# Altium schematic sheet Sist_General.SchDoc
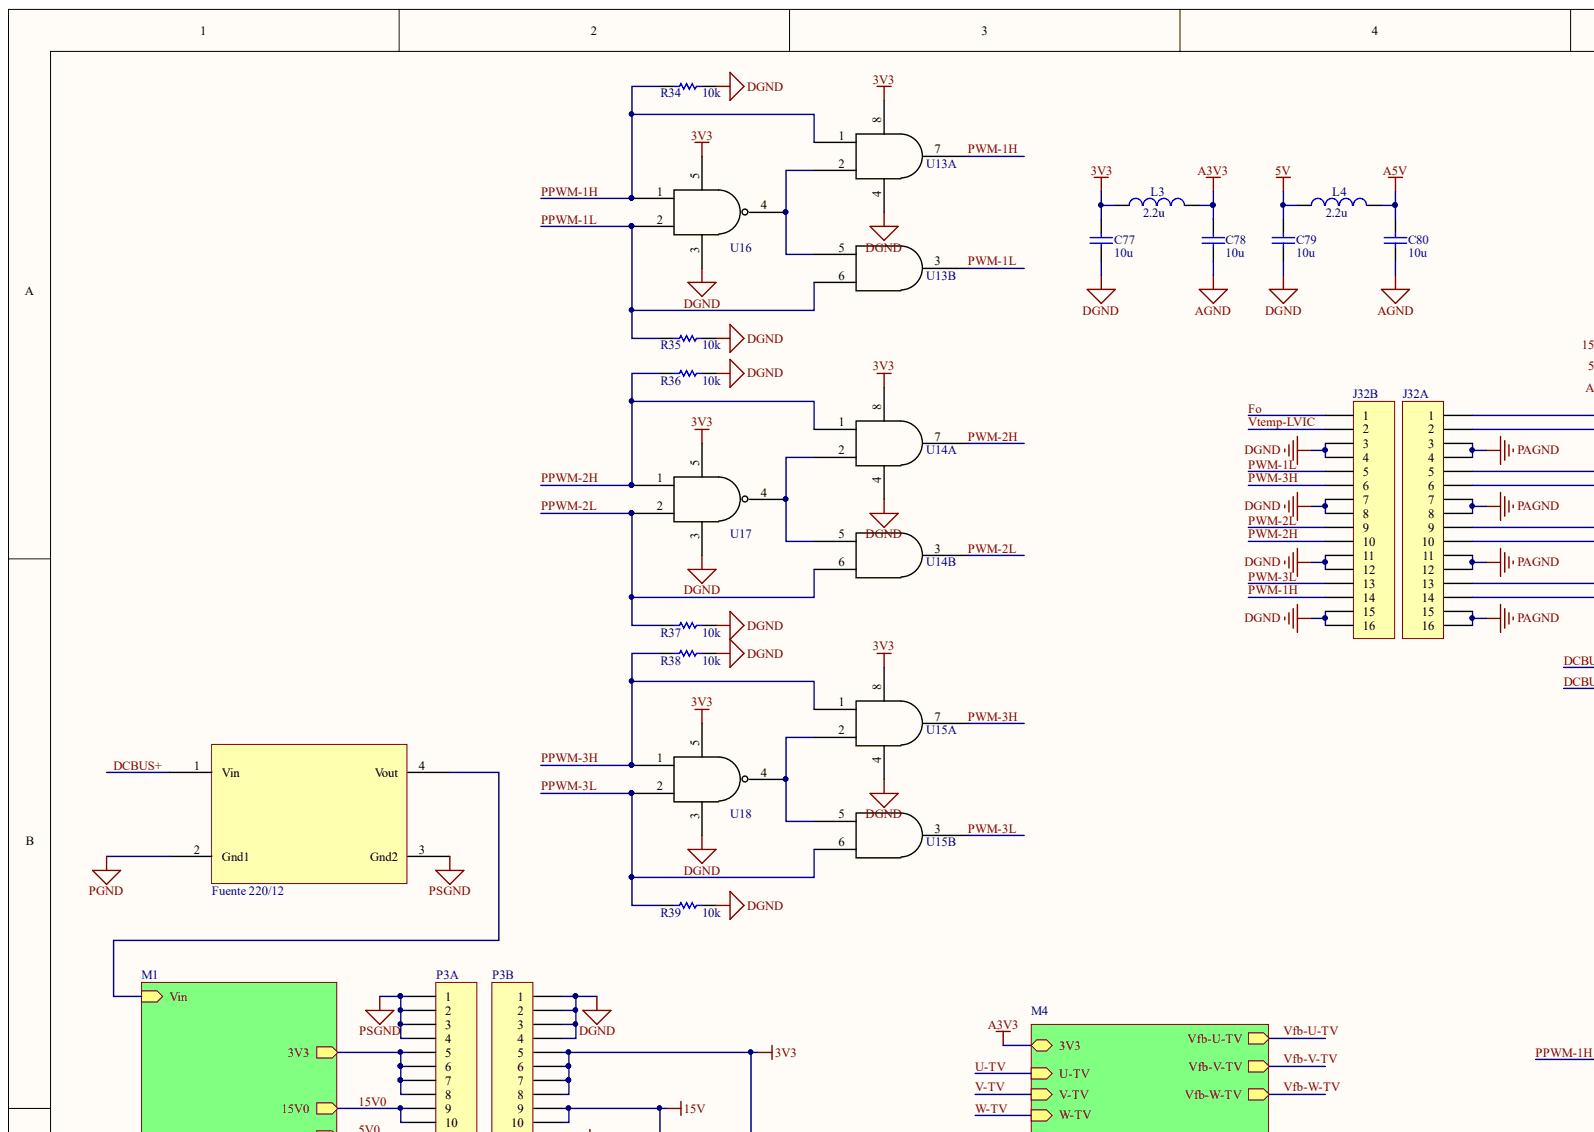
[diagram p0: Sist_General.SchDoc, top left]
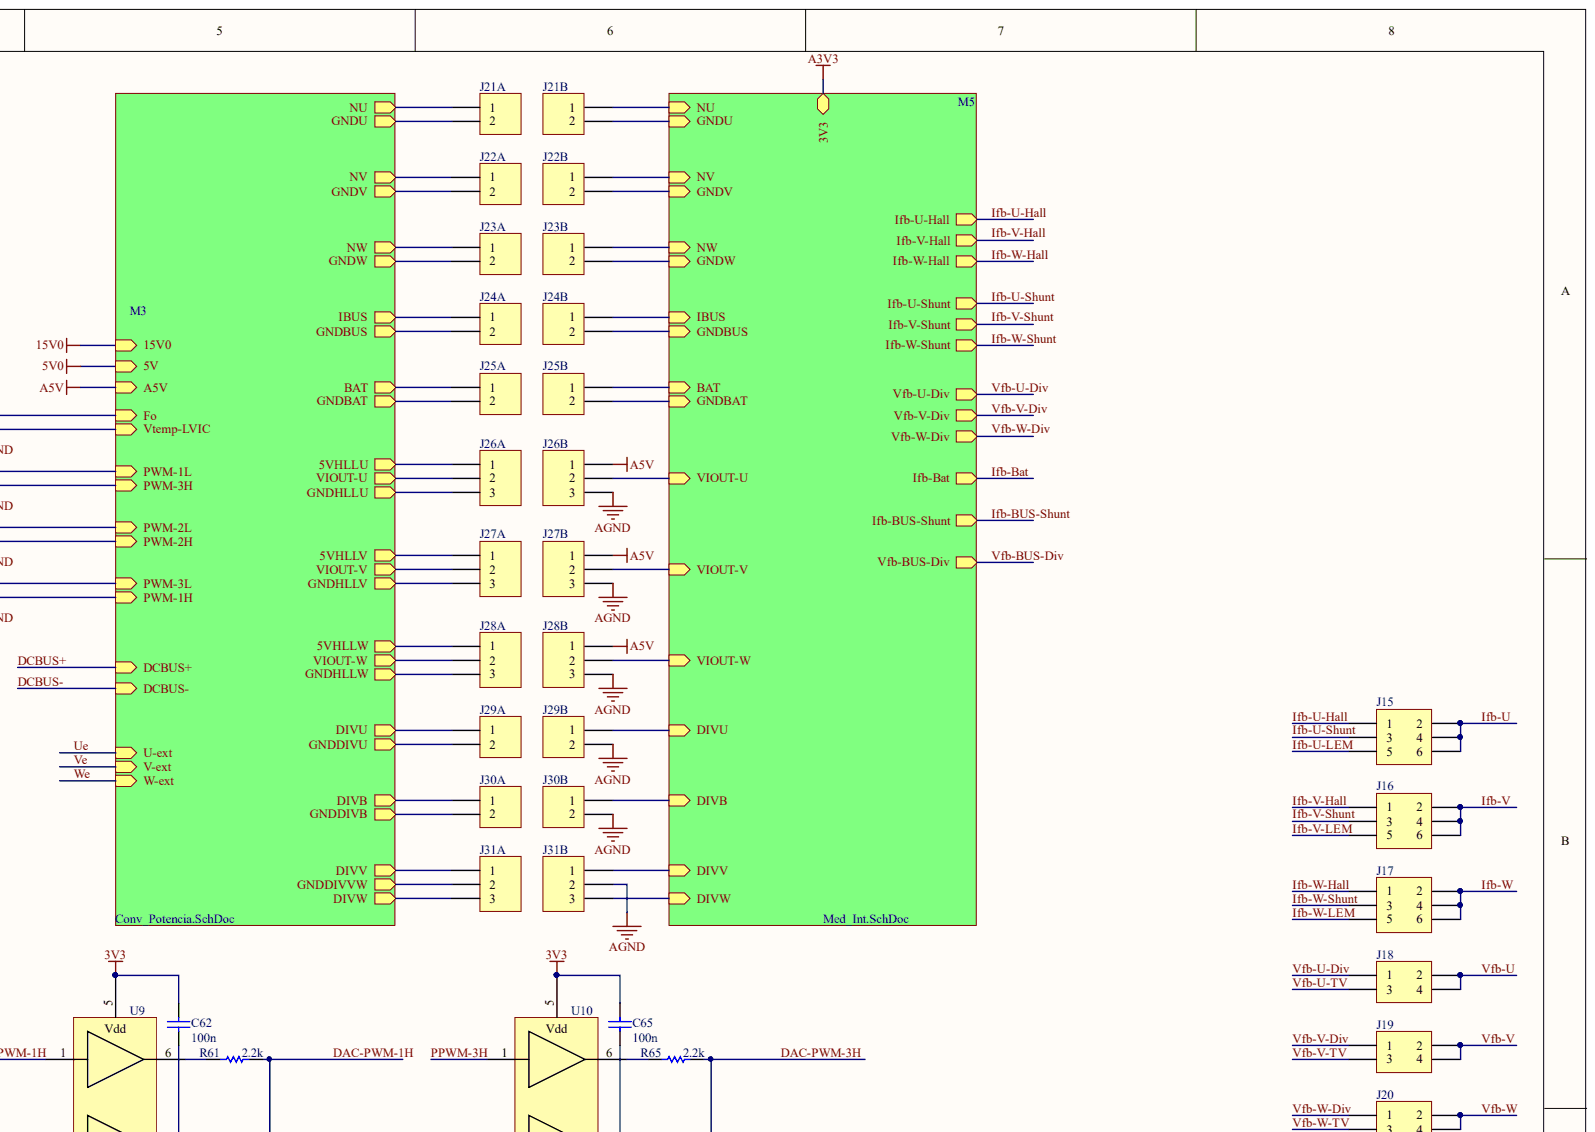
[diagram p1: Sist_General.SchDoc, top right]
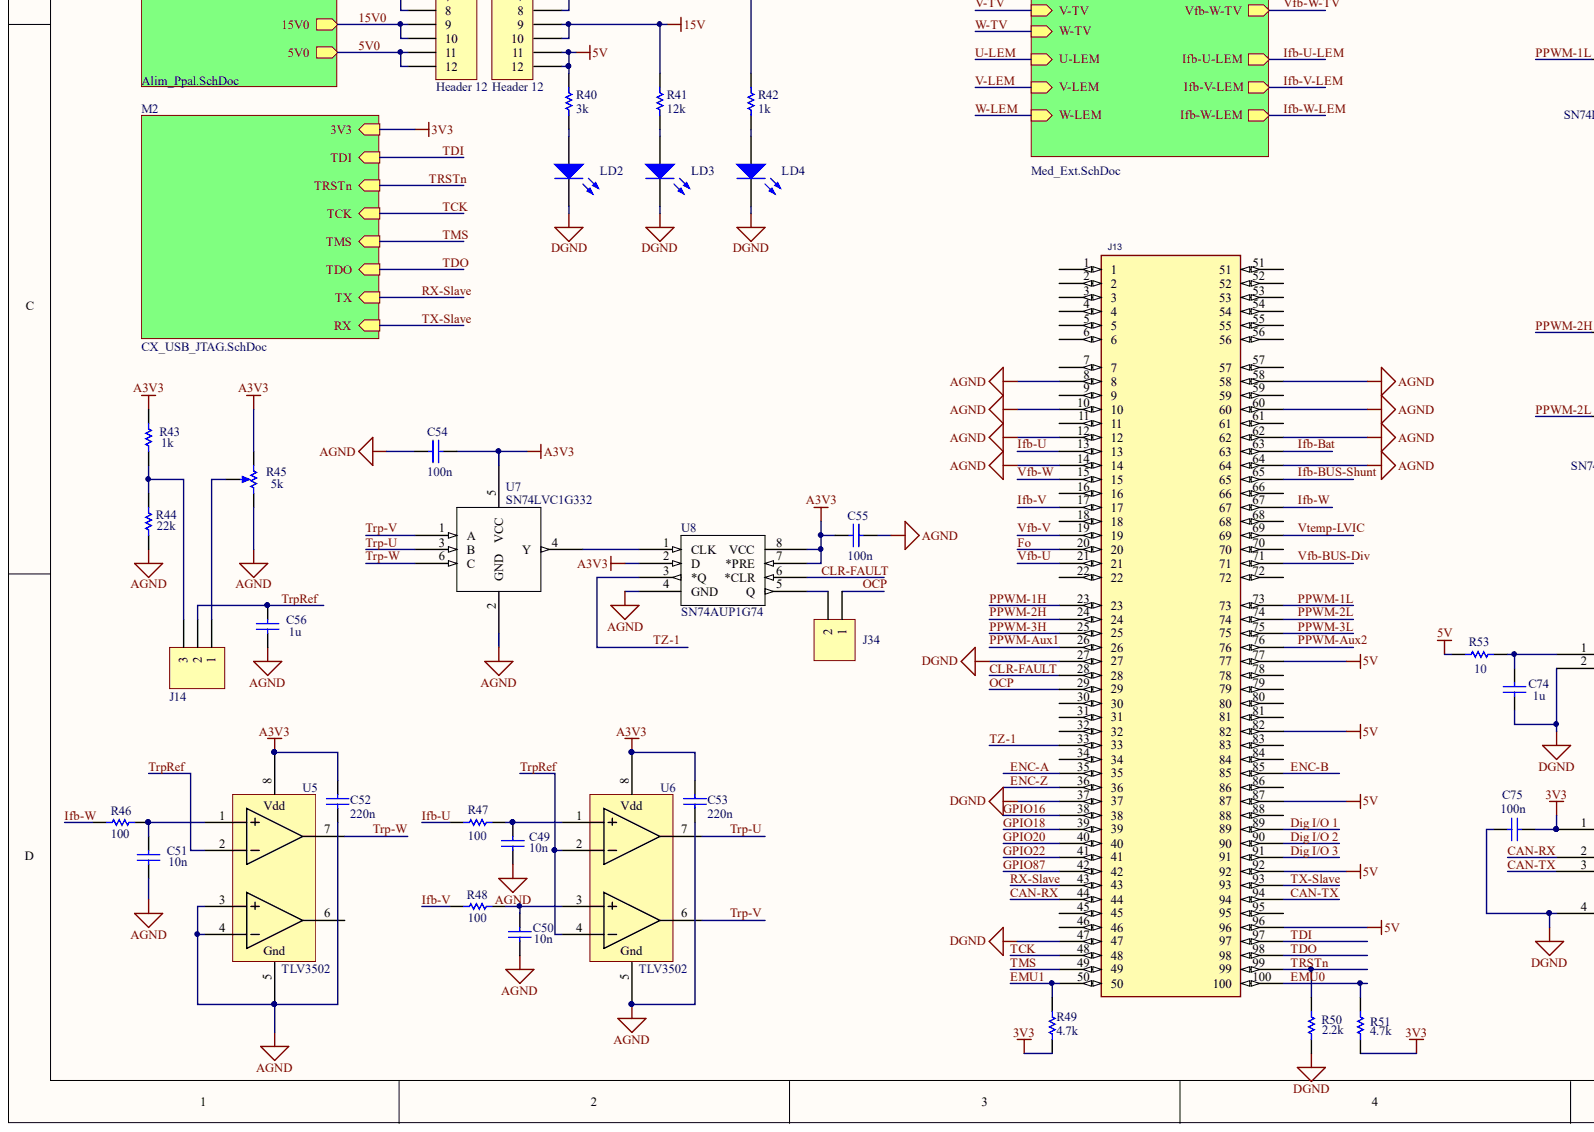
[diagram p2: Sist_General.SchDoc, bottom left]
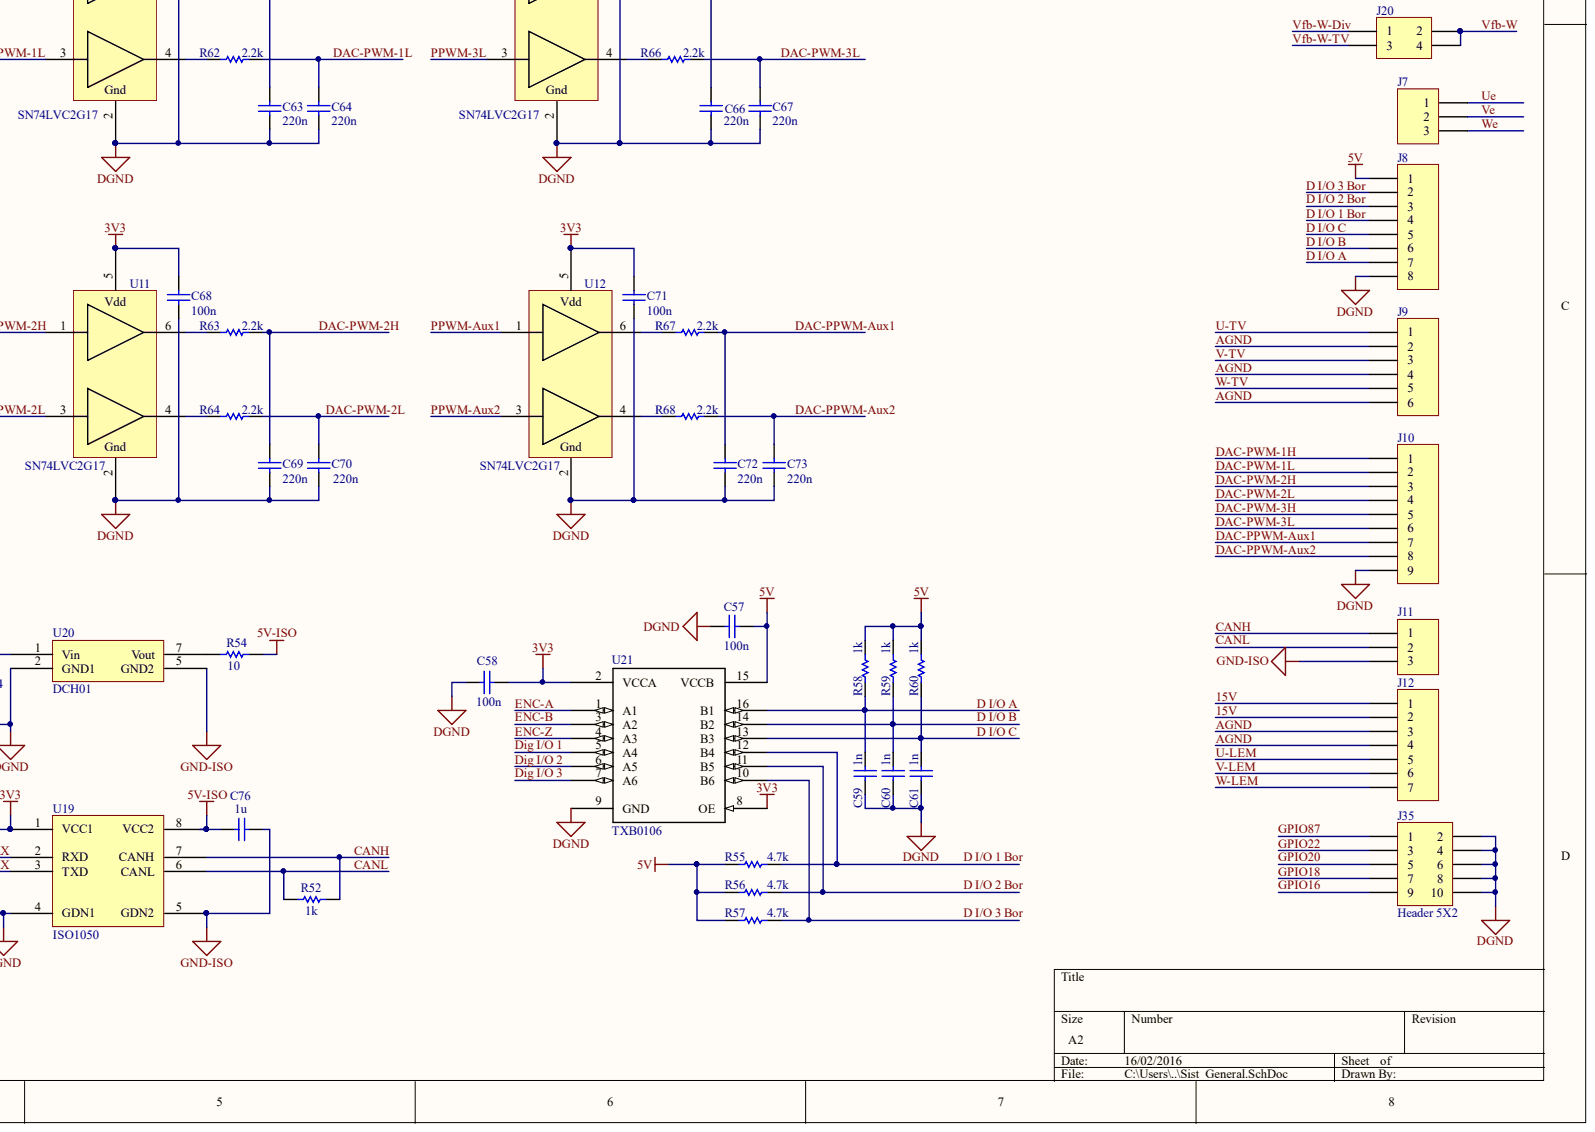
[diagram p3: Sist_General.SchDoc, bottom right]

## Parts
C49  value 10n  (hidden comment: Cap)
C50  value 10n  (hidden comment: Cap)
C51  value 10n  (hidden comment: Cap)
C52  value 220n  (hidden comment: Cap)
C53  value 220n  (hidden comment: Cap)
C54  value 100n  (hidden comment: Cap)
C55  value 100n  (hidden comment: Cap)
C56  value 1u  (hidden comment: Cap)
C57  value 100n  (hidden comment: Cap)
C58  value 100n  (hidden comment: Cap)
C59  value 1n  (hidden comment: Cap)
C60  value 1n  (hidden comment: Cap)
C61  value 1n  (hidden comment: Cap)
C62  value 100n  (hidden comment: Cap)
C63  value 220n  (hidden comment: Cap)
C64  value 220n  (hidden comment: Cap)
C65  value 100n  (hidden comment: Cap)
C66  value 220n  (hidden comment: Cap)
C67  value 220n  (hidden comment: Cap)
C68  value 100n  (hidden comment: Cap)
C69  value 220n  (hidden comment: Cap)
C70  value 220n  (hidden comment: Cap)
C71  value 100n  (hidden comment: Cap)
C72  value 220n  (hidden comment: Cap)
C73  value 220n  (hidden comment: Cap)
C74  value 1u  (hidden comment: Cap)
C75  value 100n  (hidden comment: Cap)
C76  value 1u  (hidden comment: Cap)
C77  value 10u  (hidden comment: Cap)
C78  value 10u  (hidden comment: Cap)
C79  value 10u  (hidden comment: Cap)
C80  value 10u  (hidden comment: Cap)
Fuente  Fuente 220/12
J7  Header 3  (value not drawn)
J8  Header 8H  (value not drawn)
J9  Header 6  (value not drawn)
J10  Header 9  (value not drawn)
J11  Header 3  (value not drawn)
J12  Header  (value not drawn)
J13  DIM100  (value not drawn)
J14  Header 3  (value not drawn)
J15  Header 3X2H  (value not drawn)
J16  Header 3X2H  (value not drawn)
J17  Header 3X2H  (value not drawn)
J18  Header 2X2H  (value not drawn)
J19  Header 2X2H  (value not drawn)
J20  Header 2X2H  (value not drawn)
J21A  Header 2  (value not drawn)
J21B  Header 2  (value not drawn)
J22A  Header 2  (value not drawn)
J22B  Header 2  (value not drawn)
J23A  Header 2  (value not drawn)
J23B  Header 2  (value not drawn)
J24A  Header 2  (value not drawn)
J24B  Header 2  (value not drawn)
J25A  Header 2  (value not drawn)
J25B  Header 2  (value not drawn)
J26A  Header 3  (value not drawn)
J26B  Header 3  (value not drawn)
J27A  Header 3  (value not drawn)
J27B  Header 3  (value not drawn)
J28A  Header 3  (value not drawn)
J28B  Header 3  (value not drawn)
J29A  Header 2  (value not drawn)
J29B  Header 2  (value not drawn)
J30A  Header 2  (value not drawn)
J30B  Header 2  (value not drawn)
J31A  Header 3  (value not drawn)
J31B  Header 3  (value not drawn)
J32A  Header 16  (value not drawn)
J32B  Header 16  (value not drawn)
J34  Header 2  (value not drawn)
J35  Header 5X2
L3  value 2.2u  (hidden comment: Inductor)
L4  value 2.2u  (hidden comment: Inductor)
LD2  LED0  (value not drawn)
LD3  LED0  (value not drawn)
LD4  LED0  (value not drawn)
P3A  Header 12
P3B  Header 12
R34  value 10k  (hidden comment: Res1)
R35  value 10k  (hidden comment: Res1)
R36  value 10k  (hidden comment: Res1)
R37  value 10k  (hidden comment: Res1)
R38  value 10k  (hidden comment: Res1)
R39  value 10k  (hidden comment: Res1)
R40  value 3k  (hidden comment: Res1)
R41  value 12k  (hidden comment: Res1)
R42  value 1k  (hidden comment: Res1)
R43  value 1k  (hidden comment: Res1)
R44  value 22k  (hidden comment: Res1)
R45  value 5k  (hidden comment: RPot)
R46  value 100  (hidden comment: Res1)
R47  value 100  (hidden comment: Res1)
R48  value 100  (hidden comment: Res1)
R49  value 4.7k  (hidden comment: Res1)
R50  value 2.2k  (hidden comment: Res1)
R51  value 4.7k  (hidden comment: Res1)
R52  value 1k  (hidden comment: Res1)
R53  value 10  (hidden comment: Res1)
R54  value 10  (hidden comment: Res1)
R55  value 4.7k  (hidden comment: Res1)
R56  value 4.7k  (hidden comment: Res1)
R57  value 4.7k  (hidden comment: Res1)
R58  value 1k  (hidden comment: Res1)
R59  value 1k  (hidden comment: Res1)
R60  value 1k  (hidden comment: Res1)
R61  value 2.2k  (hidden comment: Res1)
R62  value 2.2k  (hidden comment: Res1)
R63  value 2.2k  (hidden comment: Res1)
R64  value 2.2k  (hidden comment: Res1)
R65  value 2.2k  (hidden comment: Res1)
R66  value 2.2k  (hidden comment: Res1)
R67  value 2.2k  (hidden comment: Res1)
R68  value 2.2k  (hidden comment: Res1)
U5  TLV3502
U6  TLV3502
U7  SN74LVC1G332
U8  SN74AUP1G74
U9  SN74LVC2G17
U10  SN74LVC2G17
U11  SN74LVC2G17
U12  SN74LVC2G17
U13  AND  (value not drawn)  (parts A,B)
U14  AND  (value not drawn)  (parts A,B)
U15  AND  (value not drawn)  (parts A,B)
U16  NAND  (value not drawn)
U17  NAND  (value not drawn)
U18  NAND  (value not drawn)
U19  ISO1050
U20  DCH01
U21  TXB0106

## Nets
3V3: C58.2 C62.2 C65.2 C68.2 C71.2 C75.1 C77.2 L3.1 P3B.5 P3B.6 P3B.7 P3B.8 R42.2 R49.1 R51.1 U9.5 U10.5 U11.5 U12.5 U13.8 U14.8 U15.8 U16.5 U17.5 U18.5 U19.1(VCC1) U21.2(VCCA) U21.8(OE)
5V: C57.2 C79.2 J8.1 J13.77 J13.82 J13.87 J13.92 J13.96 L4.1 P3B.11 P3B.12 R40.2 R53.1 R55.1 R56.1 R57.1 R58.2 R59.2 R60.2 U21.15(VCCB)
5V-ISO: C76.1 R54.2 U19.8(VCC2)
A3V3: C52.2 C53.2 C54.2 C55.1 C78.2 L3.2 R43.2 R45.1 U5.8 U6.8 U7.5(VCC) U8.2(D) U8.7(*PRE) U8.8(VCC)
A5V: C80.2 J26B.1 J27B.1 J28B.1 L4.2
DGND: C57.1 C58.1 C59.1 C60.1 C61.1 C62.1 C63.1 C64.1 C65.1 C66.1 C67.1 C68.1 C69.1 C70.1 C71.1 C72.1 C73.1 C74.1 C75.2 C77.1 C79.1 J8.8 J10.9 J13.27 J13.37 J13.47 J32B.3 J32B.4 J32B.7 J32B.8 J32B.11 J32B.12 J32B.15 J32B.16 J35.2 J35.4 J35.6 J35.8 J35.10 LD2.2 LD3.2 LD4.2 P3B.1 P3B.2 P3B.3 P3B.4 R34.2 R35.2 R36.2 R37.2 R38.2 R39.2 R50.1 U9.2 U10.2 U11.2 U12.2 U13.4 U14.4 U15.4 U16.3 U17.3 U18.3 U19.4(GDN1) U20.2(GND1) U21.9(GND)
GND-ISO: C76.2 J11.3 U19.5(GDN2) U20.5(GND2)
PAGND: J32A.3 J32A.4 J32A.7 J32A.8 J32A.11 J32A.12 J32A.15 J32A.16
PGND: Fuente.2(Gnd1)
PSGND: Fuente.3(Gnd2) P3A.1 P3A.2 P3A.3 P3A.4
5V0: P3A.11 P3A.12
15V: J12.1 J12.2 P3B.9 P3B.10 R41.2
15V0: P3A.9 P3A.10
AGND: C49.1 C50.1 C51.1 C52.1 C53.1 C54.1 C55.2 C56.1 C78.1 C80.1 J9.2 J9.4 J9.6 J12.3 J12.4 J13.8 J13.10 J13.12 J13.14 J13.58 J13.60 J13.62 J13.64 J26B.3 J27B.3 J28B.3 J29B.2 J30B.2 J31B.2 R44.1 R45.2 U5.3 U5.4 U5.5 U6.5 U7.2(GND) U8.4(GND)
CAN-RX: J13.44 U19.2(RXD)
CAN-TX: J13.94 U19.3(TXD)
CANH: J11.1 R52.2 U19.7(CANH)
CANL: J11.2 R52.1 U19.6(CANL)
CLR-FAULT: J13.28 U8.6(*CLR)
D I/O 1 BOR: J8.4 R55.2 U21.12(B4)
D I/O 2 BOR: J8.3 R56.2 U21.11(B5)
D I/O 3 BOR: J8.2 R57.2 U21.10(B6)
D I/O A: C59.2 J8.7 R58.1 U21.16(B1)
D I/O B: C60.2 J8.6 R59.1 U21.14(B2)
D I/O C: C61.2 J8.5 R60.1 U21.13(B3)
DAC-PPWM-AUX1: C72.2 J10.7 R67.2
DAC-PPWM-AUX2: C73.2 J10.8 R68.2
DAC-PWM-1H: C63.2 J10.1 R61.2
DAC-PWM-1L: C64.2 J10.2 R62.2
DAC-PWM-2H: C69.2 J10.3 R63.2
DAC-PWM-2L: C70.2 J10.4 R64.2
DAC-PWM-3H: C66.2 J10.5 R65.2
DAC-PWM-3L: C67.2 J10.6 R66.2
DCBUS+: Fuente.1(Vin)
DIG I/O 1: J13.89 U21.5(A4)
DIG I/O 2: J13.90 U21.6(A5)
DIG I/O 3: J13.91 U21.7(A6)
EMU0: J13.100 R51.2
EMU1: J13.50 R49.2
ENC-A: J13.35 U21.1(A1)
ENC-B: J13.85 U21.3(A2)
ENC-Z: J13.36 U21.4(A3)
FO: J13.20 J32B.1
GPIO16: J13.38 J35.9
GPIO18: J13.39 J35.7
GPIO20: J13.40 J35.5
GPIO22: J13.41 J35.3
GPIO87: J13.42 J35.1
IFB-BAT: J13.63
IFB-BUS-SHUNT: J13.65
IFB-U: J13.13 J15.2 J15.4 J15.6 R47.1
IFB-U-HALL: J15.1
IFB-U-LEM: J15.5
IFB-U-SHUNT: J15.3
IFB-V: J13.17 J16.2 J16.4 J16.6 R48.1
IFB-V-HALL: J16.1
IFB-V-LEM: J16.5
IFB-V-SHUNT: J16.3
IFB-W: J13.67 J17.2 J17.4 J17.6 R46.1
IFB-W-HALL: J17.1
IFB-W-LEM: J17.5
IFB-W-SHUNT: J17.3
OCP: J13.29 J34.1
PPWM-1H: J13.23 R34.1 U9.1 U13.1 U16.1
PPWM-1L: J13.73 R35.1 U9.3 U13.6 U16.2
PPWM-2H: J13.24 R36.1 U11.1 U14.1 U17.1
PPWM-2L: J13.74 R37.1 U11.3 U14.6 U17.2
PPWM-3H: J13.25 R38.1 U10.1 U15.1 U18.1
PPWM-3L: J13.75 R39.1 U10.3 U15.6 U18.2
PPWM-AUX1: J13.26 U12.1
PPWM-AUX2: J13.76 U12.3
PWM-1H: J32B.14 U13.7
PWM-1L: J32B.5 U13.3
PWM-2H: J32B.10 U14.7
PWM-2L: J32B.9 U14.3
PWM-3H: J32B.6 U15.7
PWM-3L: J32B.13 U15.3
RX-SLAVE: J13.43
TCK: J13.48
TDI: J13.97
TDO: J13.98
TMS: J13.49
TRP-U: U6.7 U7.3(B)
TRP-V: U6.6 U7.1(A)
TRP-W: U5.7 U7.6(C)
TRPREF: C56.2 J14.2 U5.2 U6.2 U6.4
TRSTN: J13.99 R50.2
TX-SLAVE: J13.93
TZ-1: J13.33 U8.3(*Q)
U-LEM: J12.5
U-TV: J9.1
UE: J7.1
V-LEM: J12.6
V-TV: J9.3
VE: J7.2
VFB-BUS-DIV: J13.71
VFB-U: J13.21 J18.2 J18.4
VFB-U-DIV: J18.1
VFB-U-TV: J18.3
VFB-V: J13.19 J19.2 J19.4
VFB-V-DIV: J19.1
VFB-V-TV: J19.3
VFB-W: J13.15 J20.2 J20.4
VFB-W-DIV: J20.1
VFB-W-TV: J20.3
VTEMP-LVIC: J13.69 J32B.2
W-LEM: J12.7
W-TV: J9.5
WE: J7.3
NetC49_2: C49.2 R47.2 U6.1
NetC50_2: C50.2 R48.2 U6.3
NetC51_2: C51.2 R46.2 U5.1
NetC74_2: C74.2 R53.2 U20.1(Vin)
NetFuente_4: Fuente.4(Vout)
NetJ13_1: J13.1
NetJ13_2: J13.2
NetJ13_3: J13.3
NetJ13_4: J13.4
NetJ13_5: J13.5
NetJ13_6: J13.6
NetJ13_7: J13.7
NetJ13_9: J13.9
NetJ13_11: J13.11
NetJ13_16: J13.16
NetJ13_18: J13.18
NetJ13_22: J13.22
NetJ13_30: J13.30
NetJ13_31: J13.31
NetJ13_32: J13.32
NetJ13_34: J13.34
NetJ13_45: J13.45
NetJ13_46: J13.46
NetJ13_51: J13.51
NetJ13_52: J13.52
NetJ13_53: J13.53
NetJ13_54: J13.54
NetJ13_55: J13.55
NetJ13_56: J13.56
NetJ13_57: J13.57
NetJ13_59: J13.59
NetJ13_61: J13.61
NetJ13_66: J13.66
NetJ13_68: J13.68
NetJ13_70: J13.70
NetJ13_72: J13.72
NetJ13_78: J13.78
NetJ13_79: J13.79
NetJ13_80: J13.80
NetJ13_81: J13.81
NetJ13_83: J13.83
NetJ13_84: J13.84
NetJ13_86: J13.86
NetJ13_88: J13.88
NetJ13_95: J13.95
NetJ14_1: J14.1 R45.3
NetJ14_3: J14.3 R43.1 R44.2
NetJ21A_1: J21A.1
NetJ21A_2: J21A.2
NetJ21B_1: J21B.1
NetJ21B_2: J21B.2
NetJ22A_1: J22A.1
NetJ22A_2: J22A.2
NetJ22B_1: J22B.1
NetJ22B_2: J22B.2
NetJ23A_1: J23A.1
NetJ23A_2: J23A.2
NetJ23B_1: J23B.1
NetJ23B_2: J23B.2
NetJ24A_1: J24A.1
NetJ24A_2: J24A.2
NetJ24B_1: J24B.1
NetJ24B_2: J24B.2
NetJ25A_1: J25A.1
NetJ25A_2: J25A.2
NetJ25B_1: J25B.1
NetJ25B_2: J25B.2
NetJ26A_1: J26A.1
NetJ26A_2: J26A.2
NetJ26A_3: J26A.3
NetJ26B_2: J26B.2
NetJ27A_1: J27A.1
NetJ27A_2: J27A.2
NetJ27A_3: J27A.3
NetJ27B_2: J27B.2
NetJ28A_1: J28A.1
NetJ28A_2: J28A.2
NetJ28A_3: J28A.3
NetJ28B_2: J28B.2
NetJ29A_1: J29A.1
NetJ29A_2: J29A.2
NetJ29B_1: J29B.1
NetJ30A_1: J30A.1
NetJ30A_2: J30A.2
NetJ30B_1: J30B.1
NetJ31A_1: J31A.1
NetJ31A_2: J31A.2
NetJ31A_3: J31A.3
NetJ31B_1: J31B.1
NetJ31B_3: J31B.3
NetJ32A_1: J32A.1
NetJ32A_2: J32A.2
NetJ32A_5: J32A.5
NetJ32A_6: J32A.6
NetJ32A_9: J32A.9
NetJ32A_10: J32A.10
NetJ32A_13: J32A.13
NetJ32A_14: J32A.14
NetJ34_2: J34.2 U8.5(Q)
NetLD2_1: LD2.1 R40.1
NetLD3_1: LD3.1 R41.1
NetLD4_1: LD4.1 R42.1
NetP3A_5: P3A.5 P3A.6 P3A.7 P3A.8
NetR54_1: R54.1 U20.7(Vout)
NetR61_1: R61.1 U9.6
NetR62_1: R62.1 U9.4
NetR63_1: R63.1 U11.6
NetR64_1: R64.1 U11.4
NetR65_1: R65.1 U10.6
NetR66_1: R66.1 U10.4
NetR67_1: R67.1 U12.6
NetR68_1: R68.1 U12.4
NetU5_6: U5.6
NetU7_4: U7.4(Y) U8.1(CLK)
NetU13_2: U13.2 U13.5(DGND) U16.4
NetU14_2: U14.2 U14.5 U17.4
NetU15_2: U15.2 U15.5 U18.4
DCBUS-:

## Library data of the parts
C49-C80 Fuente J7-J20 J21A J21B J22A J22B J23A J23B J24A J24B J25A J25B J26A J26B J27A J27B J28A J28B J29A J29B J30A J30B J31A J31B J32A J32B J34 J35 L3 L4 LD2-LD4 P3A P3B R34-R68 U5-U21 = (no symbol name)
  J8 J11 J22A P3A P3B: LatestRevisionDate: 17-Jul-2002; LatestRevisionNote: Re-released for DXP Platform.; Publisher: Altium Limited
